# Altium schematic sheet POWER.SchDoc
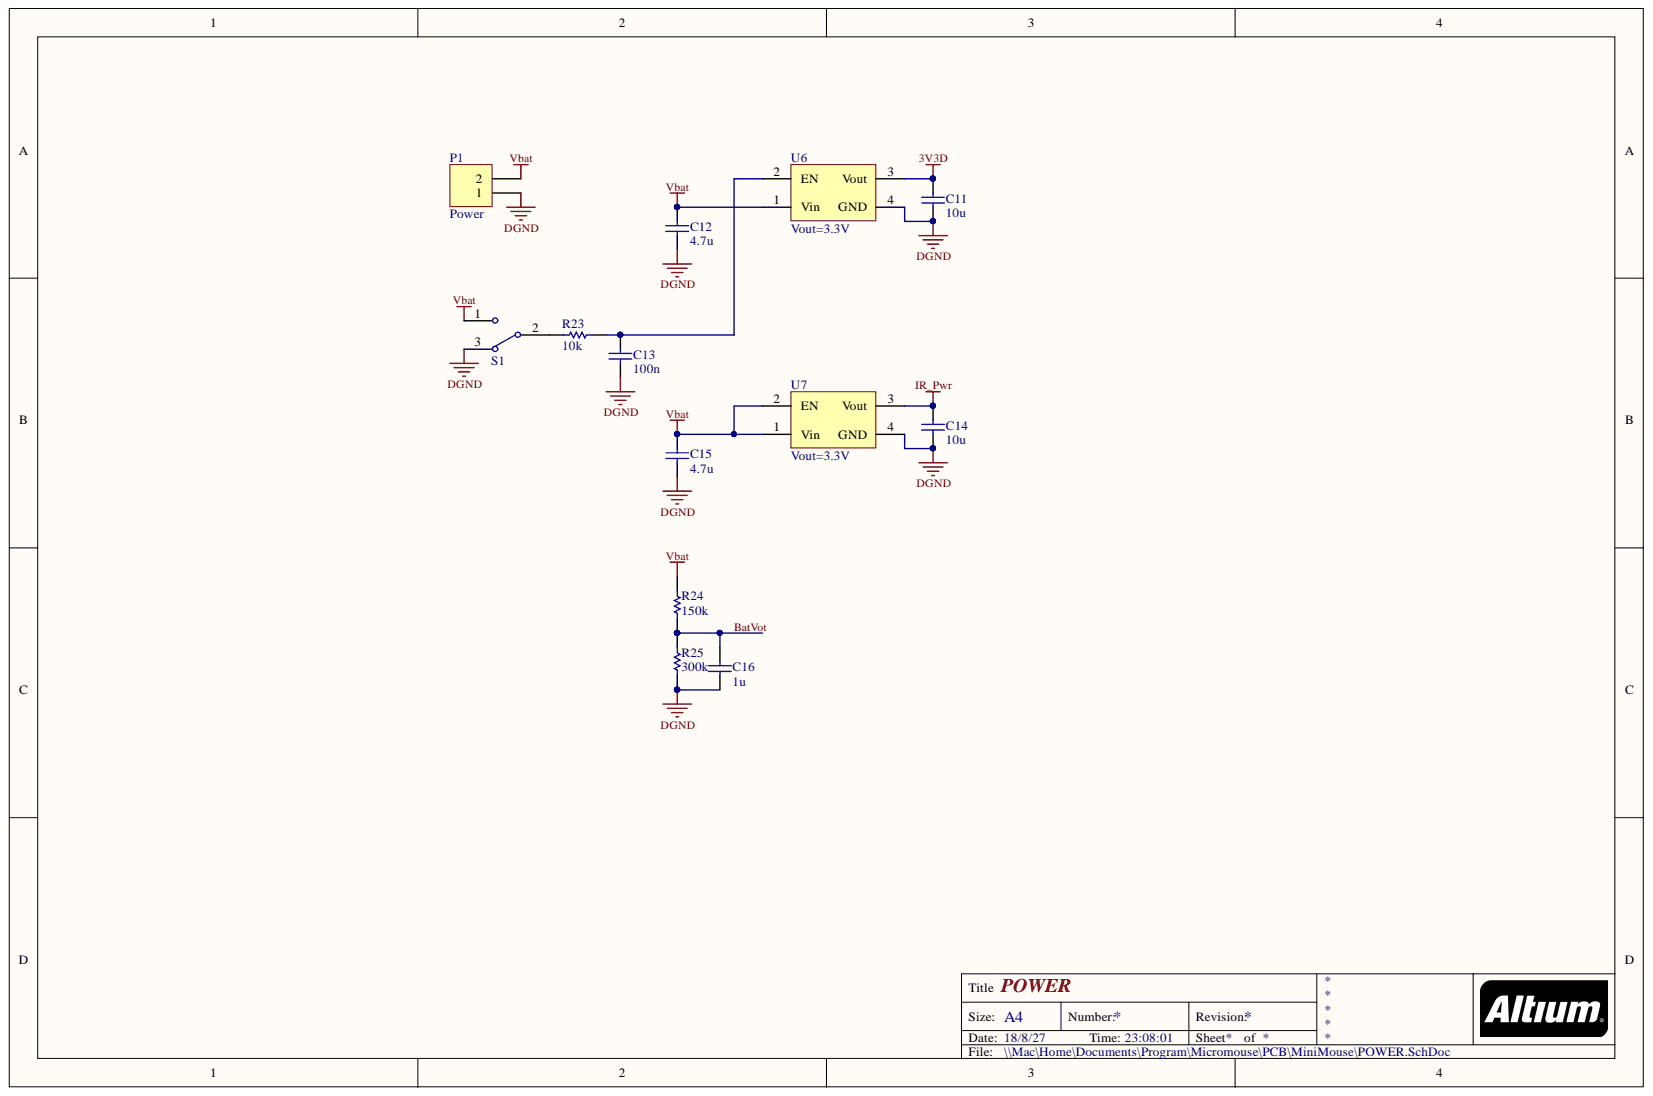
[diagram p0: POWER.SchDoc]

## Parts
C11  10u  [CAPC1005[0402]]
C12  4.7u  [CAPC1005[0402]]
C13  100n  [CAPC1005[0402]]
C14  10u  [CAPC1005[0402]]
C15  4.7u  [CAPC1005[0402]]
C16  1u  [CAPC1005[0402]]
P1  Power  [JST1.27-2]
R23  10k  [RESC1005[0402]]
R24  150k  [RESC1005[0402]]
R25  300k  [RESC1005[0402]]
S1  SW-SPDT  [SW-SPDT-6530-P1.5]  (value not drawn)
U6  Voltage: Vout=3.3V  [LXDC2HL]  (hidden comment: #NAME?)
U7  Voltage: Vout=3.3V  [LXDC2HL]  (hidden comment: #NAME?)

## Nets
3V3D: C11.2 U6.3(Vout)
DGND: C11.1 C12.1 C13.1 C14.1 C15.1 C16.1 P1.1 R25.1 S1.3 U6.4(GND) U7.4(GND)
IR_Pwr: C14.2 U7.3(Vout)
Vbat: C12.2 C15.2 P1.2 R24.2 S1.1 U6.1(Vin) U7.1(Vin) U7.2(EN)
BatVot: C16.2 R24.1 R25.2
NetC13_2: C13.2 R23.1 U6.2(EN)
NetR23_2: R23.2 S1.2

## Library data of the parts
C11-C16 = Cap: Capacitor; Component Kind: Standard; LatestRevisionDate: 17-Jul-2002; LatestRevisionNote: Re-released for DXP Platform.; Library Name: Miscellaneous Devices.IntLib; PackageDescription: Radial Cap, Thru-Hole; 2 Leads; 0.3 in Pin Spacing; PackageReference: RAD-0.3; Published: 8-Jun-2000; Signal Integrity: Cap; Simulation: CAP; Publisher: Altium Limited
  C11 C14: Value: 10u
  C12 C15: Value: 4.7u
  C13: Value: 100n
  C16: Value: 1u
P1 = Header 2: Header, 2-Pin; Component Kind: Standard; LatestRevisionDate: 17-Jul-2002; LatestRevisionNote: Re-released for DXP Platform.; Library Name: Miscellaneous Connectors.IntLib; Signal Integrity: Connector; Publisher: Altium Limited
R23-R25 = Res1: Resistor; Component Kind: Standard; LatestRevisionDate: 17-Jul-2002; LatestRevisionNote: Re-released for DXP Platform.; Library Name: Miscellaneous Devices.IntLib; PackageDescription: Axial Device, Thru-Hole; 2 Leads; 0.3 in Pin Spacing; PackageReference: AXIAL-0.3; Published: 8-Jun-2000; Signal Integrity: Res; Simulation: RESISTOR; Publisher: Altium Limited
  R23: Value: 10k
  R24: Value: 150k
  R25: Value: 300k
S1 = SW-SPDT: SPDT Subminiature Toggle Switch, Right Angle Mounting, Vertical Actuation; Component Kind: Standard; ComponentLink1Description: Manufacturer Link; ComponentLink1URL: http://www.apem.com; DatasheetVersion: 05-Dec-2000; LatestRevisionDate: 17-Dec-2003; LatestRevisionNote: Schematic revised, PCB Footprint replaced.; Library Name: Miscellaneous Devices.IntLib; PackageDescription: Switch; 4 Leads; PackageReference: TL36WW15050; Published: 24-Mar-1999; Publisher: Altium Limited
U6 U7 = LXDC2HL: Micro DC-DC Converter; Component Kind: Standard; Library Name: LIQI.SchLib
